# Altium schematic sheet Peripherals.SchDoc
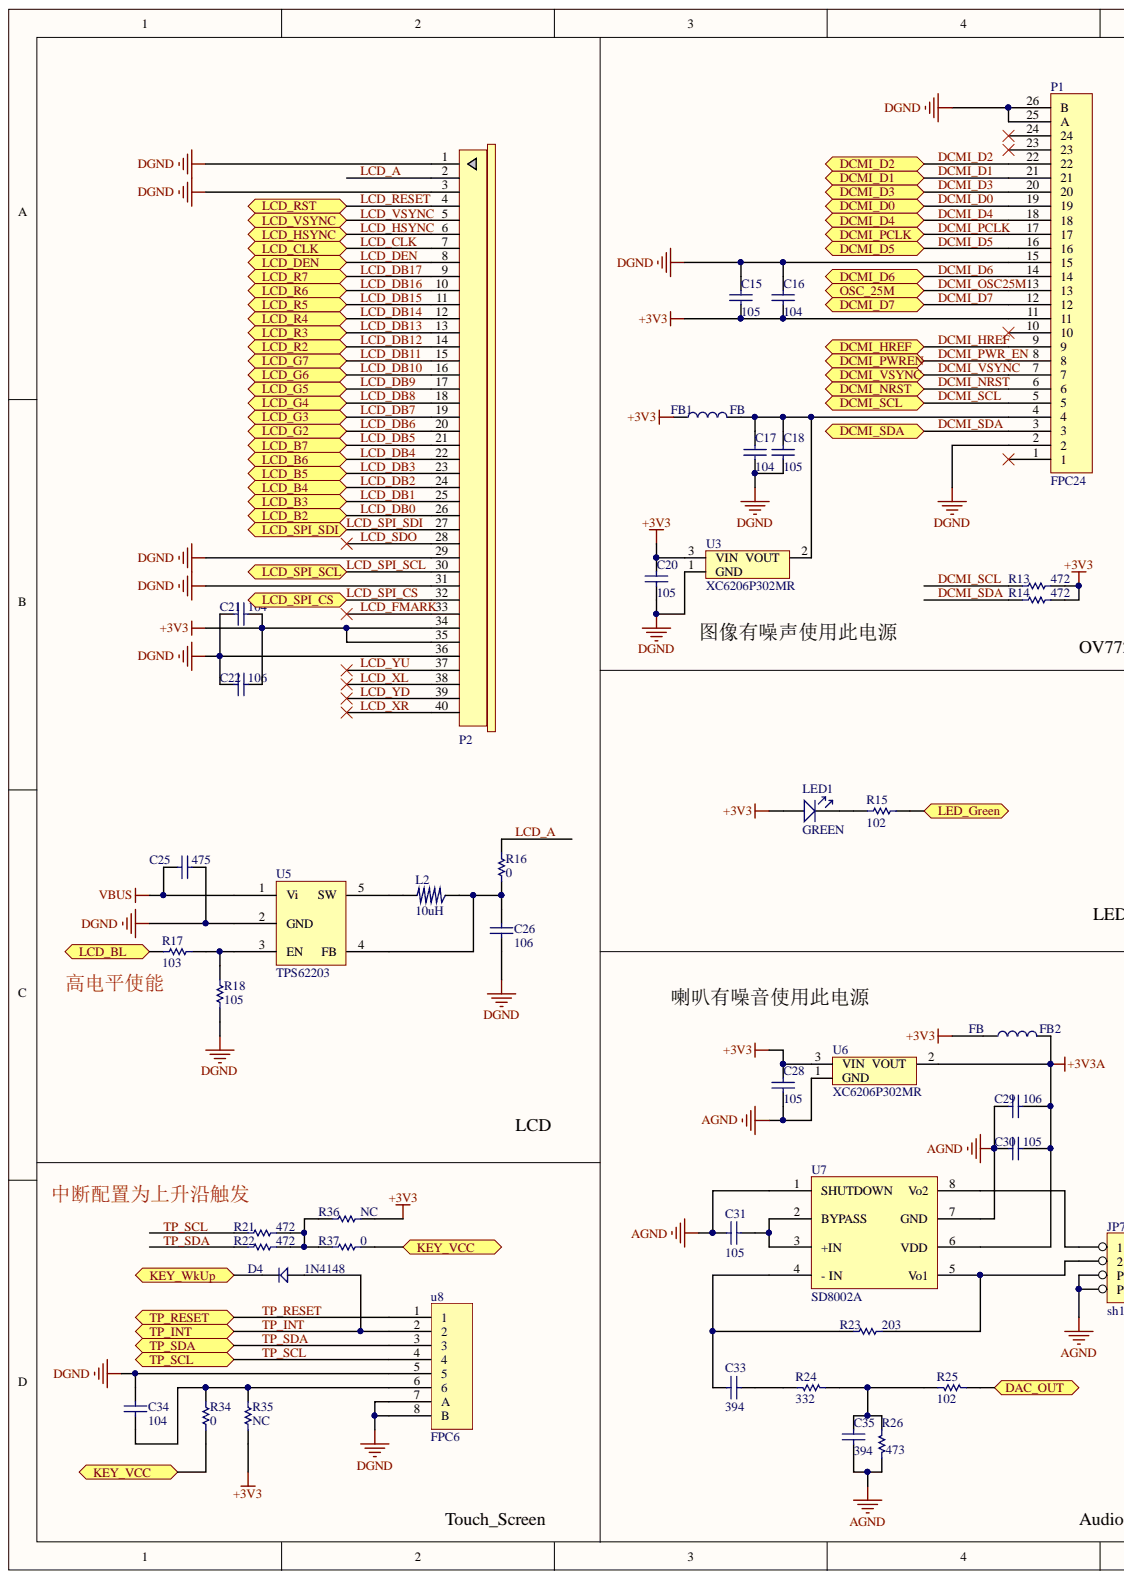
[diagram p0: Peripherals.SchDoc, left]
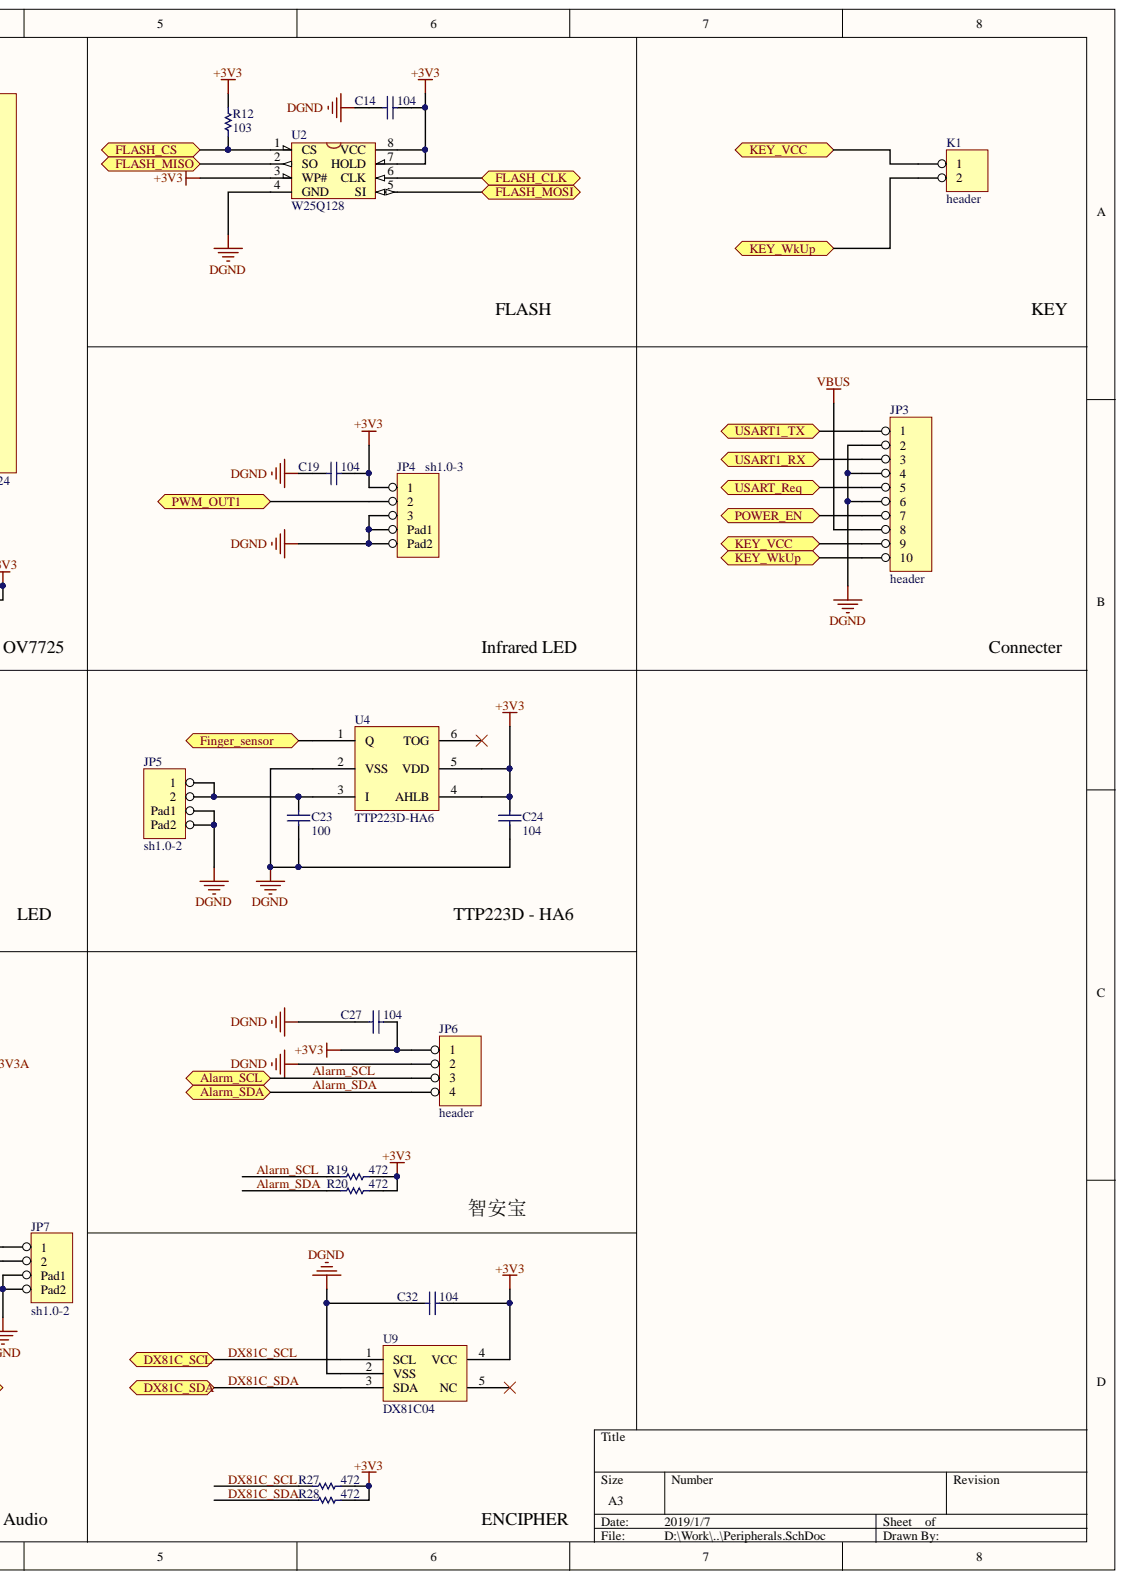
[diagram p1: Peripherals.SchDoc, right]

## Parts
C14  104  [0603_C]
C15  105  [0603_C]
C16  104  [0603_C]
C17  104  [0603_C]
C18  105  value 104  [0603_C]
C19  104  [0603_C]
C20  105  [0603_C]
C21  104  [0603_C]
C22  106  [0805_c]
C23  100  [0603_C]
C24  104  [0603_C]
C25  475  [0805_c]
C26  106  [0805_c]
C27  104  [0603_C]
C28  105  [0603_C]
C29  106  [0805_c]
C30  105  [0603_C]
C31  105  [0603_C]
C32  104  [0603_C]
C33  394  [0603_C]
C34  104  [0603_C]
C35  394  [0603_C]
D4  1N4148  [DIODE_SOP]
FB1  FB  [0805]
FB2  FB  [0805]
JP3  header  [xh2.54-10立式贴片]
JP4  sh1.0-3  [sh1.0-3]
JP5  sh1.0-2  [SH1.0-2]
JP6  header  [header4]
JP7  sh1.0-2  [SH1.0-2]
K1  header  [header2]
L2  10uH  [L_CD43]
LED1  GREEN  [SMDLED-0603]
P1  FPC24  [FPC24]
P2  FPC  [FPC-TOP40]  (value not drawn)
R12  103  [0603_R]
R13  472  [0603_R]
R14  472  [0603_R]
R15  102  [0603_R]
R16  0  [0603_R]
R17  103  [0603_R]
R18  105  [0603_R]
R19  472  [0603_R]
R20  472  [0603_R]
R21  472  [0603_R]
R22  472  [0603_R]
R23  203  [0603_R]
R24  332  [0603_R]
R25  102  [0603_R]
R26  473  [0603_R]
R27  472  [0603_R]
R28  472  [0603_R]
R34  0  [0603_R]
R35  NC  [0603_R]
R36  NC  [0603_R]
R37  0  [0603_R]
U2  W25Q128  [SOIC-8]
U3  XC6206P302MR  [SOT-23]
U4  TTP223D-HA6  [SOT23-6]
U5  TPS62203  [SOT23-5]
U6  XC6206P302MR  [SOT-23]
U7  SD8002A  [SOP8]
u8  FPC6  [FPC6]
U9  DX81C04  [SOT23-5]

## Ports
ALARM_SCL, ALARM_SDA, DAC_OUT, DCMI_D0, DCMI_D1, DCMI_D2, DCMI_D3, DCMI_D4, DCMI_D5, DCMI_D6, DCMI_D7, DCMI_HREF, DCMI_NRST, DCMI_PCLK, DCMI_PWREN, DCMI_SCL, DCMI_SDA, DCMI_VSYNC, DX81C_SCL, DX81C_SDA, FINGER_SENSOR, FLASH_CLK, FLASH_CS, FLASH_MISO, FLASH_MOSI, KEY_VCC, KEY_WKUP, LCD_B2, LCD_B3, LCD_B4, LCD_B5, LCD_B6, LCD_B7, LCD_BL, LCD_CLK, LCD_DEN, LCD_G2, LCD_G3, LCD_G4, LCD_G5, LCD_G6, LCD_G7, LCD_HSYNC, LCD_R2, LCD_R3, LCD_R4, LCD_R5, LCD_R6, LCD_R7, LCD_RST, LCD_SPI_CS, LCD_SPI_SCL, LCD_SPI_SDI, LCD_VSYNC, LED_GREEN, OSC_25M, POWER_EN, PWM_OUT1, TP_INT, TP_RESET, TP_SCL, TP_SDA, USART1_RX, USART1_TX, USART_REQ

## Nets
+3V3: C14.1 C15.1 C16.1 C19.2 C20.2 C21.1 C22.1 C24.2 C27.2 C28.2 C32.2 FB1.1 FB2.1 JP4.1 JP6.1 LED1.1 P1.11 P2.34 P2.35 R12.2 R13.1 R14.1 R19.1 R20.1 R27.1 R28.1 R35.1 R36.2 U2.3(WP#) U2.7(HOLD) U2.8(VCC) U3.3 U4.4(AHLB) U4.5(VDD) U6.3 U9.4(VCC)
+3V3A: C29.2 C30.2 FB2.2 U6.2 U7.6(VDD)
AGND: C28.1 C29.1 C30.1 C31.1 C35.1 JP7.Pad1 JP7.Pad2 R26.1 U6.1 U7.1(SHUTDOWN) U7.7(GND)
DGND: C14.2 C15.2 C16.2 C17.1 C18.1 C19.1 C20.1 C21.2 C22.2 C23.1 C24.1 C25.1 C26.1 C27.1 C32.1 C34.2 JP3.2 JP3.4 JP3.6 JP4.3 JP4.Pad1 JP4.Pad2 JP5.Pad1 JP5.Pad2 JP6.2 P1.2 P1.15 P1.25(A) P1.26(B) P2.1 P2.3 P2.29 P2.31 P2.36 R18.1 U2.4(GND) U3.1 U4.2(VSS) U5.2(GND) u8.5 u8.7(A) u8.8(B) U9.2(VSS)
VBUS: C25.2 JP3.8 U5.1(Vi)
ALARM_SCL: JP6.3 R19.2 ; port ALARM_SCL
ALARM_SDA: JP6.4 R20.2 ; port ALARM_SDA
DAC_OUT: R25.1 ; port DAC_OUT
DCMI_D0: P1.19 ; port DCMI_D0
DCMI_D1: P1.21 ; port DCMI_D1
DCMI_D2: P1.22 ; port DCMI_D2
DCMI_D3: P1.20 ; port DCMI_D3
DCMI_D4: P1.18 ; port DCMI_D4
DCMI_D5: P1.16 ; port DCMI_D5
DCMI_D6: P1.14 ; port DCMI_D6
DCMI_D7: P1.12 ; port DCMI_D7
DCMI_HREF: P1.9 ; port DCMI_HREF
DCMI_NRST: P1.6 ; port DCMI_NRST
DCMI_OSC25M: P1.13 ; port OSC_25M
DCMI_PCLK: P1.17 ; port DCMI_PCLK
DCMI_PWR_EN: P1.8 ; port DCMI_PWREN
DCMI_SCL: P1.5 R13.2 ; port DCMI_SCL
DCMI_SDA: P1.3 R14.2 ; port DCMI_SDA
DCMI_VSYNC: P1.7 ; port DCMI_VSYNC
DX81C_SCL: R27.2 U9.1(SCL) ; port DX81C_SCL
DX81C_SDA: R28.2 U9.3(SDA) ; port DX81C_SDA
FLASH_CLK: U2.6(CLK) ; port FLASH_CLK
FLASH_CS: R12.1 U2.1(CS) ; port FLASH_CS
FLASH_MISO: U2.2(SO) ; port FLASH_MISO
FLASH_MOSI: U2.5(SI) ; port FLASH_MOSI
KEY_VCC: JP3.9 K1.1 R34.1 R37.2 ; port KEY_VCC
LCD_A: P2.2 R16.2
LCD_BL: R17.1 ; port LCD_BL
LCD_CLK: P2.7 ; port LCD_CLK
LCD_DB0: P2.26 ; port LCD_B2
LCD_DB1: P2.25 ; port LCD_B3
LCD_DB2: P2.24 ; port LCD_B4
LCD_DB3: P2.23 ; port LCD_B5
LCD_DB4: P2.22 ; port LCD_B6
LCD_DB5: P2.21 ; port LCD_B7
LCD_DB6: P2.20 ; port LCD_G2
LCD_DB7: P2.19 ; port LCD_G3
LCD_DB8: P2.18 ; port LCD_G4
LCD_DB9: P2.17 ; port LCD_G5
LCD_DB10: P2.16 ; port LCD_G6
LCD_DB11: P2.15 ; port LCD_G7
LCD_DB12: P2.14 ; port LCD_R2
LCD_DB13: P2.13 ; port LCD_R3
LCD_DB14: P2.12 ; port LCD_R4
LCD_DB15: P2.11 ; port LCD_R5
LCD_DB16: P2.10 ; port LCD_R6
LCD_DB17: P2.9 ; port LCD_R7
LCD_DEN: P2.8 ; port LCD_DEN
LCD_FMARK: P2.33
LCD_HSYNC: P2.6 ; port LCD_HSYNC
LCD_RESET: P2.4 ; port LCD_RST
LCD_SDO: P2.28
LCD_SPI_CS: P2.32 ; port LCD_SPI_CS
LCD_SPI_SCL: P2.30 ; port LCD_SPI_SCL
LCD_SPI_SDI: P2.27 ; port LCD_SPI_SDI
LCD_VSYNC: P2.5 ; port LCD_VSYNC
LCD_XL: P2.38
LCD_XR: P2.40
LCD_YD: P2.39
LCD_YU: P2.37
POWER_EN: JP3.7 ; port POWER_EN
PWM_OUT1: JP4.2 ; port PWM_OUT1
TP_INT: D4.1 u8.2 ; port TP_INT
TP_RESET: u8.1 ; port TP_RESET
TP_SCL: R21.2 u8.4 ; port TP_SCL
TP_SDA: R22.2 u8.3 ; port TP_SDA
USART1_RX: JP3.3 ; port USART1_RX
USART1_TX: JP3.1 ; port USART1_TX
NetC17_2: C17.2 C18.2 FB1.2 P1.4 U3.2
NetC23_2: C23.2 JP5.1 JP5.2 U4.3(I)
NetC26_2: C26.2 L2.1 R16.1 U5.4(FB)
NetC31_2: C31.2 U7.2(BYPASS) U7.3(+IN)
NetC33_1: C33.1 R23.1 U7.4(-_IN)
NetC33_2: C33.2 R24.2
NetC34_1: C34.1 R34.2 R35.2 u8.6
NetC35_2: C35.2 R24.1 R25.2 R26.2
NetD4_2: D4.2 JP3.10 K1.2 ; port KEY_WKUP
NetJP3_5: JP3.5 ; port USART_REQ
NetJP7_1: JP7.1 U7.8(Vo2)
NetJP7_2: JP7.2 R23.2 U7.5(Vo1)
NetL2_2: L2.2 U5.5(SW)
NetLED1_2: LED1.2 R15.1
NetR15_2: R15.2 ; port LED_GREEN
NetR17_2: R17.2 R18.2 U5.3(EN)
NetR21_1: R21.1 R22.1 R36.1 R37.1
NetU4_1: U4.1(Q) ; port FINGER_SENSOR

Not connected: P1 1 10 23 24; U4 6(TOG); U9 5(NC)

## Library data of the parts
C14 C16-C19 C21 C24 C27 C32 C34 = CAP: Capacitor; Component Kind: Standard; LatestRevisionDate: 17-Jul-2002; LatestRevisionNote: Re-released for DXP Platform.; Library Name: My Footprint.IntLib; PackageReference: RAD-0.3; Published: 8-Jun-2000; Publisher: Altium Limited
  C14 C16 C17 C19 C21 C24 C27 C32 C34: Value: 104
C15 C20 C22 C23 C25 C26 C28-C31 C33 C35 = CAP: Capacitor; Value: 104; Component Kind: Standard; LatestRevisionDate: 17-Jul-2002; LatestRevisionNote: Re-released for DXP Platform.; Library Name: My Footprint.IntLib; PackageReference: RAD-0.3; Published: 8-Jun-2000; Publisher: Altium Limited
D4 = D: Component Kind: Standard; Library Name: 阿波罗STM32F4&F7底板封装库.IntLib
FB1 FB2 = FB: 磁珠; Component Kind: Standard; Library Name: My Footprint.IntLib
JP3 = HEADER 10: Component Kind: Standard; Library Name: My Footprint.IntLib
JP6 = HEADER 4: Component Kind: Standard; Library Name: My Footprint.IntLib
K1 = HEADER 2: Component Kind: Standard; Library Name: My Footprint.IntLib
L2 = L_SOP: Component Kind: Standard; Library Name: ATK-7016&7084 7寸RGB屏模块封装库.IntLib
LED1 = LED: Component Kind: Standard; Library Name: STM32H743核心板封装库.IntLib
P2 = FPC-40P: FPC排线连接器; Component Kind: Standard; Library Name: Fszhang.IntLib
R12-R28 R34-R37 = RES: Resistor; Value: 1K; Component Kind: Standard; Library Name: My Footprint.IntLib; Published: 8-Jun-2000; Revision: July-2002: Re-released for DXP Platform.; Publisher: Altium Limited
U2 = W25X16: W25X16;SST25VF016;MX25L1605; Component Kind: Standard; Library Name: Explorer STM32F407封装库.IntLib
U3 U6 = XC6206: LDO,SOT23,1.2~5.0V; Component Kind: Standard; Library Name: ATK-OV5640摄像头模块封装库.IntLib
U4 = TTP223-HA6: Component Kind: Standard; Library Name: MySchLib.SchLib
U5 = TPS6220x: Component Kind: Standard; Library Name: MySchLib.SchLib
JP4 = sh1.0-3: Component Kind: Standard; Library Name: MySchLib.SchLib
JP5 JP7 = sh1.0-2: Component Kind: Standard; Library Name: MySchLib.SchLib
P1 = FPC24: Component Kind: Standard; Library Name: MySchLib.SchLib
U7 = SD8002A: Component Kind: Standard; Library Name: MySchLib.SchLib
u8 = FPC6: Component Kind: Standard; Library Name: MySchLib.SchLib
U9 = DX81C04: Component Kind: Standard; Library Name: MySchLib.SchLib
